# Altium schematic sheet Power.SchDoc(Power)
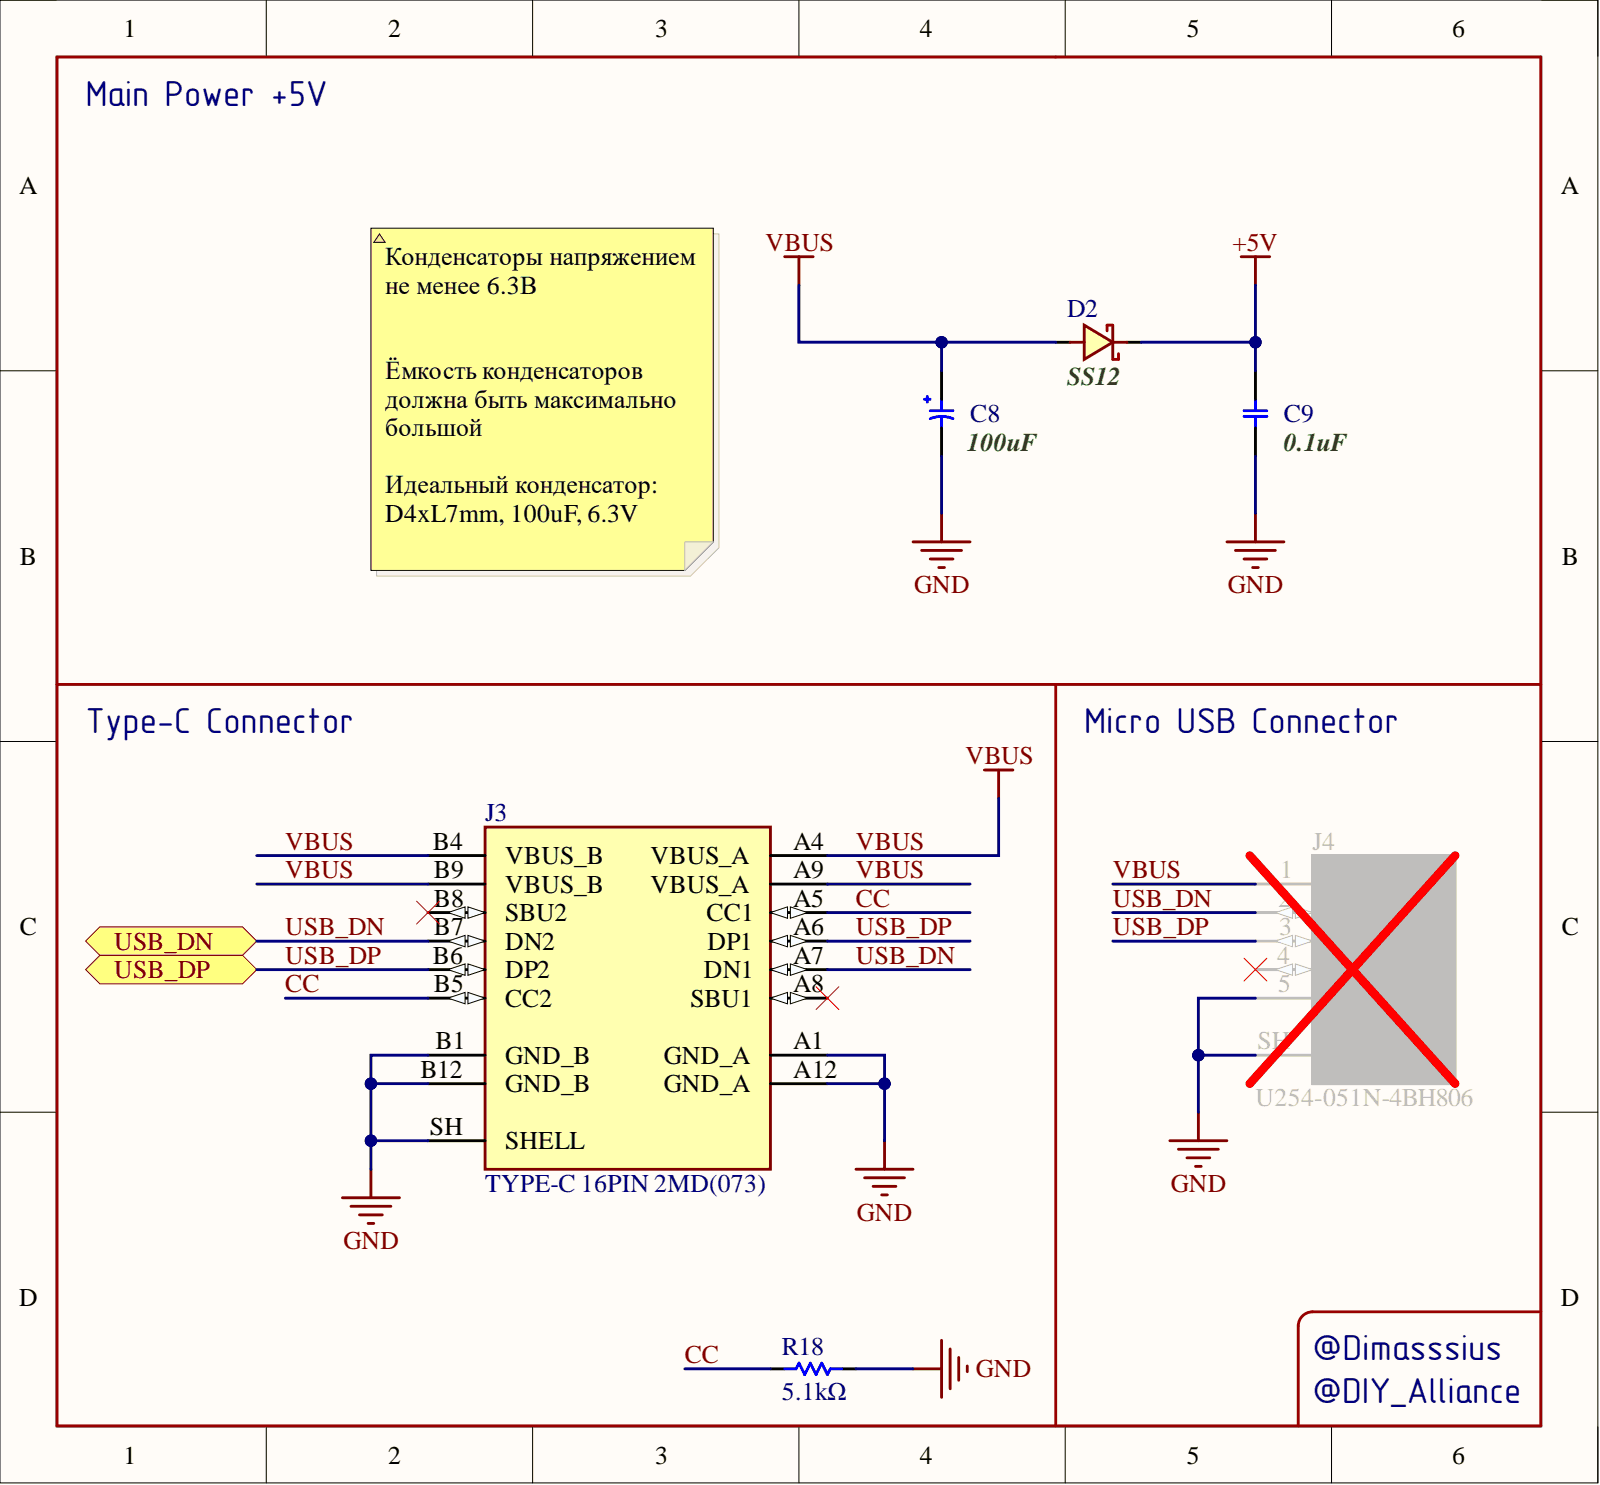
[diagram p0: Power.SchDoc]

## Parts
C8  100uF  [CAP_THD_ELEC_ALUM_D4.0MM_H7.0MM_THD/SMD_SMD]
C8
C8
C9  0.1uF  [CAP_SMD_MLCC_C0603_(M1608)_C0402A_(M1005A)_THD/SMD_SMD]
C9
C9
D2  SS12  [DO-41/DO-214AC_VERTICAL_SMD/THD_SMD]
D2
D2
J3  TYPE-C 16PIN 2MD(073)  [USB_C_DOUBLE_V/H_HORIZONTAL]
J3
J4  U254-051N-4BH806  [USB_MIICRO_HORIZONTAL_3]
J4
J4
R18  5.1kΩ  [RES_SMD_R0603_(M1608)_R0402A_(M1005A)]

## Ports
USB_DN, USB_DP

## Nets
+5V: C9.1 D2.1
GND: C8.2 C9.2 J3.A1 J3.A12 J3.B1 J3.B12 J3.SH J4.5 J4.SH R18.2
CC: J3.A5 J3.B5 R18.1
USB_DN: J3.A7 J3.B7 J4.2 ; port USB_DN
USB_DP: J3.A6 J3.B6 J4.3 ; port USB_DP
VBUS: C8.1 D2.2 J3.A4 J3.A9 J3.B4 J3.B9 J4.1

Not connected: J3 A8 B8; J4 4

## Library data of the parts
C8 = CAP_SMD_ELEC_ALUM_100UF_6.3V: Capacitor, Aluminum Electrolytic Capacitor, SMD, D5xL5.4mm, 100uF, 6.3V, ±20%, Aluminum Electrolytic Capacitors - SMD, ROHS; Component Kind: Standard; Library Name: Capacitors.SchLib; Value: 100uF
C9 = CAP_SMD_MLCC_0.1UF_6.3V: Capacitor, Ceramic (MLCC), SMD, 100nF, 6.3V, ±10%, X7R, Multilayer Ceramic Capacitors MLCC - SMD/SMT, ROHS; Manufacturer: Murata Electronics North America; Part Number: LLM315R71C104MA11L; @ qty: 0; Minimum Quantity: 1; Tolerance: ±20%; Unit Price (USD): 0.9; Voltage: 16V; Applications: Bypass, Decoupling; Component Kind: Standard; Datasheets: http://www.murata.com/~/media/webrenewal/support/library/catalog/products/capacitor/mlcc/c02e.pdf; Digi-Key Part Number: 490-4495-1-ND; Factory Stock: 0; Features: Low ESL (Multi-Terminal); Height - Seated (Max): -; Image: //media.digikey.com/Renders/Murata%20Renders/1206(LLM315).jpg; Lead Spacing: -; Lead Style: -; Library Name: Capacitors.SchLib; Mounting Type: Surface Mount, MLCC; Operating Temperature: -55°C ~ 125°C; Package / Case: -; Packaging: Cut Tape (CT); Part Status: Active; Quantity Available: 2915; Ratings: -; Series: LLM; Size / Dimension: -; Temperature Coefficient: X7R; Thickness (Max): -; Value: 0.1uF
D2 = SS12: Diode Schottky, SMD, SMA(DO-214AC), 20V, 1A,  500mV@1A, Schottky Diodes, ROHS; Component Kind: Standard; Datasheet Link: https://datasheet.datasheetarchive.com/originals/distributors/Datasheets-24/DSA-463612.pdf; Height: 2.5mm; Library Name: SamacSys.SchLib; Value: SS12
J3 = TYPE-C 16PIN 2MD(073): USB Connector, 5A, USB 3.1, Horizontal Attachment, 16P, Female, -25℃~+85℃, Type-C, SMD, USB Connectors, ROHS; Component Kind: Standard; Library Name: SamacSys.SchLib; Value: TYPE-C 16PIN 2MD(073)
J4 = U254-051N-4BH806: USB Connector, 1.8A, USB 2.0, Horizontal Attachment, 5P, Female, -20℃~+85℃, Micro-B, SMD, USB Connectors, ROHS; Component Kind: Standard; Library Name: SamacSys.SchLib; Manufacturer_Part_Number: U254-051N-4BH806; Value: U254-051N-4BH806
R18 = RES_SMD_5.1KΩ: Resistor, Thick Film Resistor, SMD, 5.1kΩ, ±5%, Chip Resistor - Surface Mount, ROHS; Component Kind: Standard; Library Name: Resistors.SchLib; Value: 5.1kΩ
